# Altium schematic sheet Sheet1.SchDoc(Sheet1)
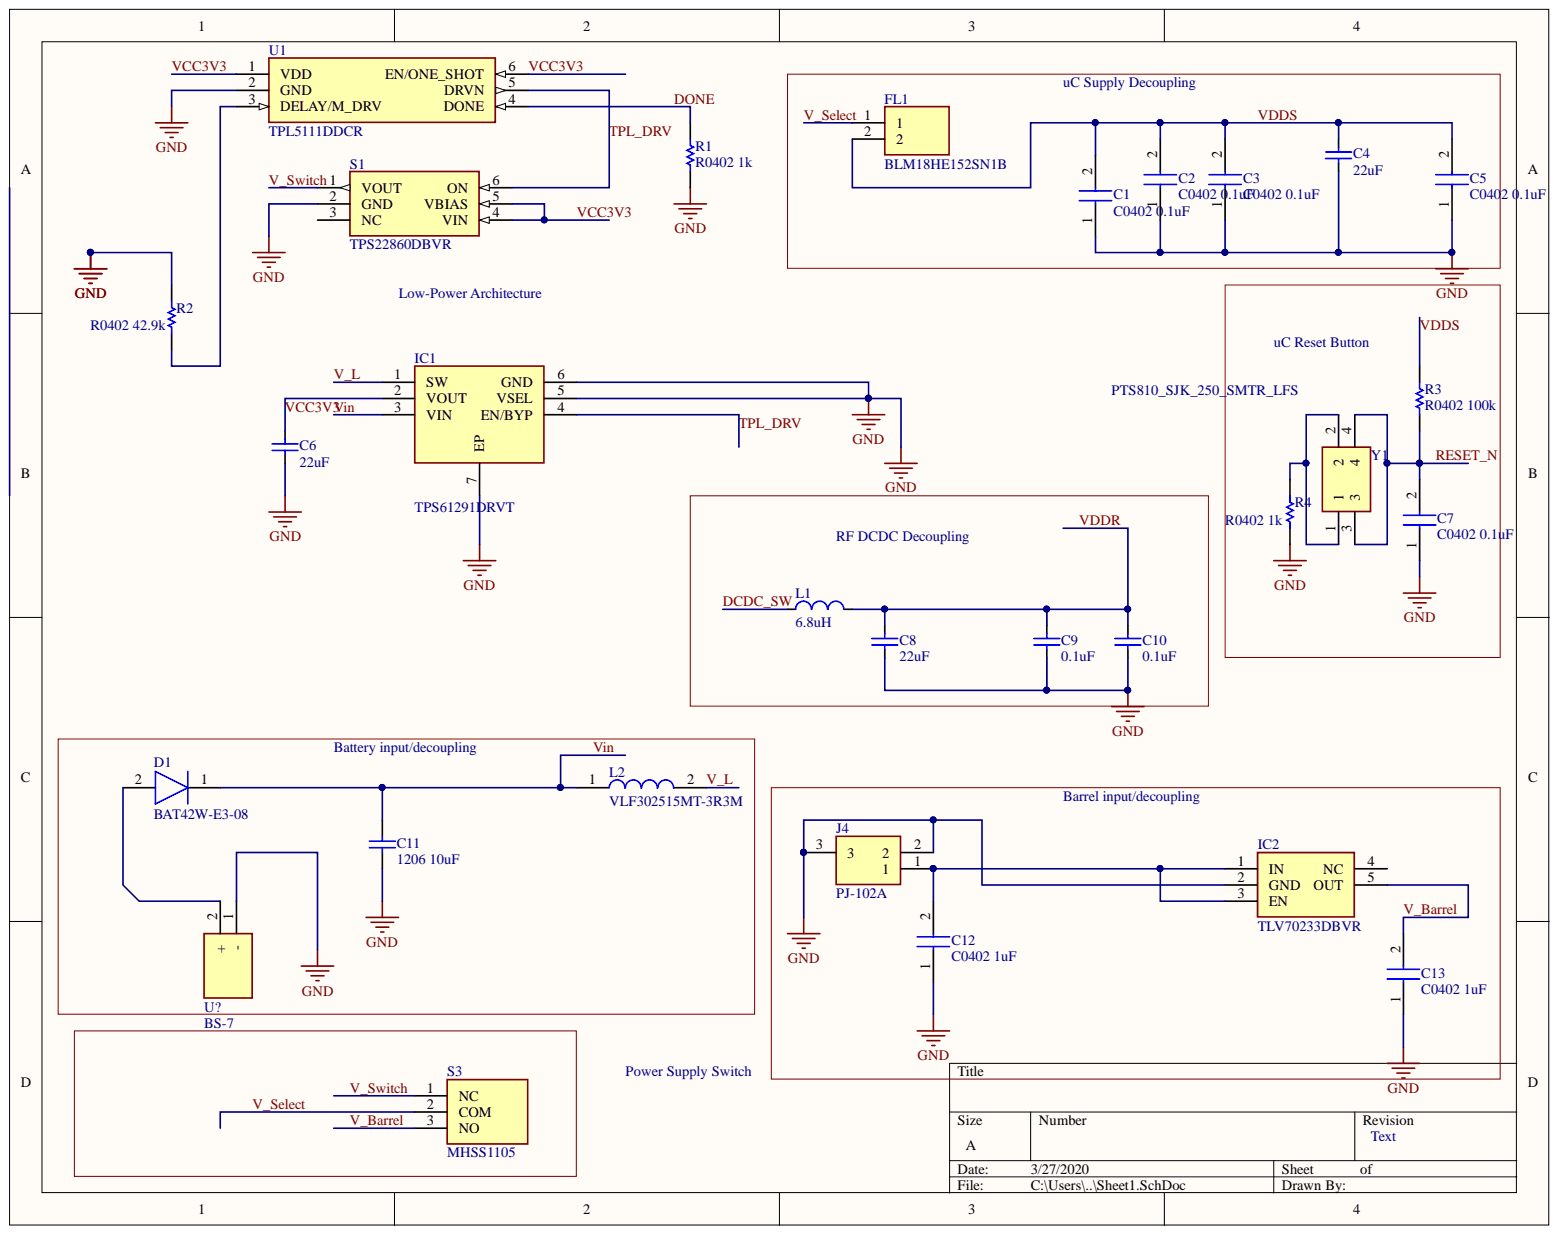
[diagram p0: Sheet1.SchDoc]

## Parts
C1  C0402 0.1uF  [CAPC0603X33N]
C2  C0402 0.1uF  [CAPC0603X33N]
C3  C0402 0.1uF  [CAPC0603X33N]
C4  value 22uF  [PASSIVE-CAPACITOR-0603]
C5  C0402 0.1uF  [CAPC0603X33N]
C6  value 22uF  [PASSIVE-CAPACITOR-0603]  (hidden comment: Passive-Capacitor (Generic))
C7  C0402 0.1uF  [CAPC0603X33N]
C8  value 22uF  [PASSIVE-CAPACITOR-0603]
C9  value 0.1uF  [PASSIVE-CAPACITOR-0603]  (hidden comment: Passive-Capacitor (Generic))
C10  value 0.1uF  [PASSIVE-CAPACITOR-0603]  (hidden comment: Passive-Capacitor (Generic))
C11  1206 10uF  [KEMT-1206-2_M]
C12  C0402 1uF  [CAPC0603X33N]
C13  C0402 1uF  [CAPC0603X33N]
D1  BAT42W-E3-08  [SOD3716X135N]
FL1  BLM18HE152SN1B  [BEADC1608X95N]
IC1  TPS61291DRVT  [SON65P200X200X80-7N]
IC2  TLV70233DBVR  [SOT95P280X145-5N]
J4  PJ-102A  [PJ-102AH]
L1  value 6.8uH  [PASSIVE-INDUCTOR-0603]  (hidden comment: Passive-Inductor (Generic))
L2  VLF302515MT-3R3M  [VLF302515MT]
R1  R0402 1k  [RESC1005X40X25NL05T05]
R2  R0402 42.9k  [RESC1005X40X25NL05T05]
R3  R0402 100k  [RESC1005X40X25NL05T05]
R4  R0402 1k  [RESC1005X40X25NL05T05]
S1  TPS22860DBVR  [SOT95P280X145-6N]
S3  MHSS1105  [MHSS1105]
U1  TPL5111DDCR  [SOT95P280X110-6N]
U?  BS-7  [BS7]
Y1  PTS810_SJK_250_SMTR_LFS  [PTS810SJK250SMTRLFS]

## Nets
GND: C1.1 C2.1 C3.1 C4.2 C5.1 C6.1 C7.1 C8.2 C9.1 C10.1 C11.1 C12.1 C13.1 IC1.5(VSEL) IC1.6(GND) IC1.7(EP) IC2.2(GND) J4.2 J4.3 R1.1 R2.2 R4.1 S1.2(GND) U1.2(GND) U?.1
DCDC_SW: L1.1
DONE: R1.2 U1.4(DONE)
RESET_N: C7.2 R3.1 Y1.3 Y1.4
TPL_DRV: IC1.4(EN/BYP) S1.6(ON) U1.5(DRVN)
V_Barrel: C13.2 IC2.5(OUT) S3.3
V_L: IC1.1(SW) L2.2
V_Select: FL1.1 S3.2
V_Switch: S1.1(VOUT) S3.1
VCC3V3: C6.2 IC1.2(VOUT) S1.4(VIN) S1.5(VBIAS) U1.1(VDD) U1.6(EN/ONE_SHOT)
VDDR: C8.1 C9.2 C10.2 L1.2
VDDS: C1.2 C2.2 C3.2 C4.1 C5.2 FL1.2 R3.2
Vin: C11.2 D1.1 IC1.3(VIN) L2.1
NetC12_2: C12.2 IC2.1(IN) IC2.3(EN) J4.1
NetD1_2: D1.2 U?.2
NetR2_1: R2.1 U1.3(DELAY/M_DRV)
NetR4_2: R4.2 Y1.1 Y1.2
N00004:

Not connected: IC2 4(NC); S1 3(NC)

## Library data of the parts
C1-C3 C5 C7 C12 C13 = 02014D474MAT2A: Capacitor; Manufacturer_Name: AVX; Mouser Price/Stock: https://www.mouser.com/Search/Refine.aspx?Keyword=581-02014D474MAT2A; Mouser Part Number: 581-02014D474MAT2A; Manufacturer_Part_Number: 02014D474MAT2A; Height: 0.33mm; Datasheet Link: http://www.mouser.com/catalog/supplier/library/pdf/AVXSurfaceMountCeramic.pdf; Component Kind: Standard
C4 C6 C8-C10 = Passive-Capacitor (Generic): Generic Capacitor; Supplier 2: Digi-Key; Supplier 1: Mouser; Library Name: MasterLib.IntLib; Component Kind: Standard; Category: Passive
C11 = CMP-2000-05169-1: CAP, Ceramic, 0.1 uF, +/- 10%, 50 V, -55 to 125 degC, 1206 (3216 Metric), RoHS, Tape and Reel; Manufacturer: Kemet; Voltage Rating: 50V; SVHC: ToBeAdvised; Product Range: KEMET-CSeries; Dielectric Characteristic: X7R; Capacitor Case Style: 1206[3216Metric]; Capacitance: 0.1µF; Packaging: Tape&Reel; Package Reference: 1206-2; Package Description: 2-Leads SMD, Body 3.2 x 1.6 mm; Operating Temperature Min: -55degC; Operating Temperature Max: 125degC; Manufacturer URL: http://www.kemet.com/; Library Name: Altium Content Vault; Datasheet Version: Jul-13; Datasheet URL: https://datasheet.ciiva.com/6125/1725438-6125494.pdf; Component Kind: Standard; Capacitance Tolerance: ±10%
D1 = BAT42W-E3-08: Diode; Manufacturer_Name: Vishay; RS Price/Stock: http://uk.rs-online.com/web/p/products/1808601P; RS Part Number: 1808601P; Mouser Price/Stock: https://www.mouser.com/Search/Refine.aspx?Keyword=78-BAT42W-E3-08; Mouser Part Number: 78-BAT42W-E3-08; Manufacturer_Part_Number: BAT42W-E3-08; Height: 1.35mm; Datasheet Link: http://www.vishay.com/docs/85661/bat42w.pdf; Component Kind: Standard
FL1 = BLM18HE152SN1B: Filter; Manufacturer_Name: Murata Electronics; Mouser Price/Stock: https://www.mouser.com/Search/Refine.aspx?Keyword=N%2FA; Mouser Part Number: N/A; Manufacturer_Part_Number: BLM18HE152SN1B; Height: 0.95mm; Datasheet Link: https://www.murata.com/en-us/products/productdetail?partno=BLM18HE152SN1%23; Component Kind: Standard
IC1 = TPS61291DRVT: Integrated Circuit; Manufacturer_Name: Texas Instruments; RS Price/Stock: http://uk.rs-online.com/web/p/products/9143112P; RS Part Number: 9143112P; Mouser Price/Stock: https://www.mouser.com/Search/Refine.aspx?Keyword=595-TPS61291DRVT; Mouser Part Number: 595-TPS61291DRVT; Manufacturer_Part_Number: TPS61291DRVT; Height: 0.8mm; Datasheet Link: http://uk.rs-online.com/web/p/products/9143112P; Component Kind: Standard; Library Name: Enviornmental_Sensor.IntLib
IC2 = TLV70233DBVR: Integrated Circuit; Manufacturer_Name: Texas Instruments; Mouser Price/Stock: https://www.mouser.com/Search/Refine.aspx?Keyword=595-TLV70233DBVR; Mouser Part Number: 595-TLV70233DBVR; Manufacturer_Part_Number: TLV70233DBVR; Height: 1.45mm; Datasheet Link: http://www.ti.com/lit/gpn/tlv702; Component Kind: Standard
J4 = PJ-102A: Connector; Manufacturer_Name: CUI; Mouser Price/Stock: https://www.mouser.com/Search/Refine.aspx?Keyword=490-PJ-102A; Mouser Part Number: 490-PJ-102A; Manufacturer_Part_Number: PJ-102A; Datasheet Link: https://www.cui.com/product/resource/pj-102a.pdf; Component Kind: Standard; Library Name: Enviornmental_Sensor.IntLib
L1 = Passive-Inductor (Generic): Generic Inductor; Supplier 2: Digi-Key; Supplier 1: Mouser; Library Name: MasterLib.IntLib; Component Kind: Standard; Category: Passive
L2 = VLF302515MT-3R3M: Inductor; Manufacturer_Name: TDK; Mouser Price/Stock: https://www.mouser.com/Search/Refine.aspx?Keyword=810-VLF302515MT-3R3M; Mouser Part Number: 810-VLF302515MT-3R3M; Manufacturer_Part_Number: VLF302515MT-3R3M; Datasheet Link: https://product.tdk.com/info/en/documents/wdcatalog/withdrawn_inductor_commercial_power_vlf302515mt_en.pdf; Component Kind: Standard
R1-R4 = CMP-2002-08135-1: Part Number: CRCW040210K0FKED; Manufacturer: Vishay Dale; Library Name: Altium Content Vault; Component Kind: Standard
S1 = TPS22860DBVR: Switch; Manufacturer_Name: Texas Instruments; Mouser Price/Stock: https://www.mouser.com/Search/Refine.aspx?Keyword=595-TPS22860DBVR; Mouser Part Number: 595-TPS22860DBVR; Manufacturer_Part_Number: TPS22860DBVR; Height: 1.45mm; Datasheet Link: http://www.ti.com/lit/gpn/tps22860; Component Kind: Standard; Library Name: Enviornmental_Sensor.IntLib
S3 = MHSS1105: Switch; Manufacturer_Name: Apem; Mouser Price/Stock: https://www.mouser.com/Search/Refine.aspx?Keyword=642-MHSS1105; Mouser Part Number: 642-MHSS1105; Manufacturer_Part_Number: MHSS1105; Height: 4mm; Datasheet Link: https://componentsearchengine.com/Datasheets/2/MHSS1105.pdf; Component Kind: Standard; Library Name: Enviornmental_Sensor.IntLib
U1 = TPL5111DDCR: Undefined or Miscellaneous; Manufacturer_Name: Texas Instruments; Mouser Price/Stock: https://www.mouser.com/Search/Refine.aspx?Keyword=595-TPL5111DDCR; Mouser Part Number: 595-TPL5111DDCR; Manufacturer_Part_Number: TPL5111DDCR; Height: 1.1mm; Datasheet Link: http://www.ti.com/lit/ds/symlink/tpl5111.pdf; Component Kind: Standard; Library Name: Enviornmental_Sensor.IntLib
U? = BS-7: Undefined or Miscellaneous; Manufacturer_Name: MPD (Memory Protection Devices); Manufacturer_Part_Number: BS-7; Height: 9mm; Datasheet Link: http://www.memoryprotectiondevices.com/datasheets/BS-7-datasheet.pdf; Component Kind: Standard; Library Name: Enviornmental_Sensor.IntLib
Y1 = PTS810_SJK_250_SMTR_LFS: Crystal or Oscillator; Manufacturer_Part_Number: PTS810 SJK 250 SMTR LFS; Manufacturer_Name: C & K COMPONENTS; Mouser Price/Stock: https://www.mouser.com/Search/Refine.aspx?Keyword=611-PTS810SJK250SMTR; Mouser Part Number: 611-PTS810SJK250SMTR; Height: 2mm; Datasheet Link: https://www.ckswitches.com/media/1476/pts810.pdf; Component Kind: Standard
